# Altium schematic sheet Sheet4.SchDoc(Sheet4)
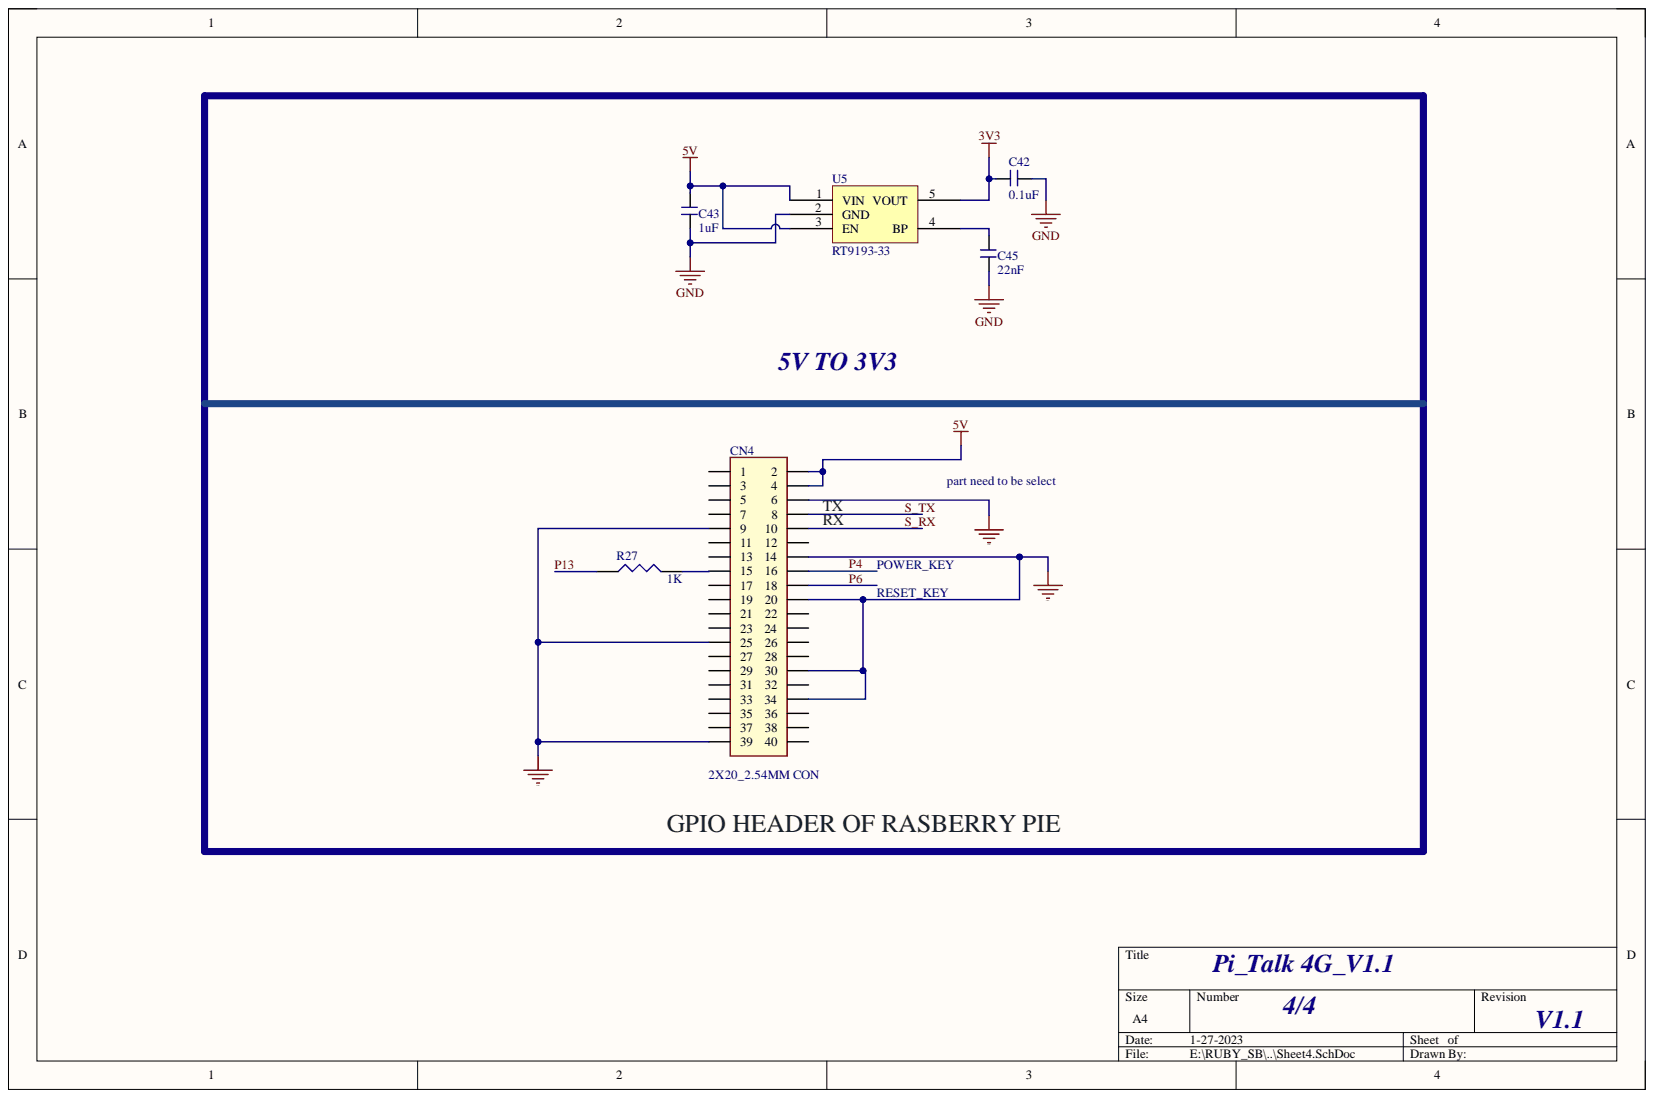
[diagram p0: Sheet4.SchDoc]

## Parts
C42  0.1uF  [CAP_0603_NONPOLAR]
C43  1uF  [CAP_0603_NONPOLAR]
C45  22nF  [CAP_0603_NONPOLAR]
CN4  2X20_2.54MM CON  [2X20_2.54mm_CON_40P]
R27  1K  [0603]
U5  RT9193-33  [SOT-23-5]

## Nets
3V3: C42.2 U5.5(VOUT)
5V: C43.1 CN4.2 CN4.4 U5.1(VIN) U5.3(EN)
GND: C42.1 C43.2 C45.2 CN4.6 CN4.9 CN4.14 CN4.20 CN4.25 CN4.30 CN4.34 CN4.39 U5.2(GND)
P4: CN4.16
P6: CN4.18
P13: R27.1
S_RX: CN4.10
S_TX: CN4.8
NetC45_1: C45.1 U5.4(BP)
NetCN4_15: CN4.15 R27.2

Not connected: CN4 1 3 5 7 11 12 13 17 19 21 22 23 24 26 27 28 29 31 32 33 35 36 37 38 40

## Library data of the parts
C42 C43 C45 = CAP_NONPOLAR: Component Kind: Standard; Library Name: dxp_pasl_lib.IntLib
CN4 = CON40_DD: Component Kind: Standard; Library Name: dxp_pasl_lib.IntLib
R27 = RES: Component Kind: Standard; Library Name: dxp_pasl_lib.IntLib
U5 = RT9193-33: Component Kind: Standard; Library Name: dxp_pasl_lib.IntLib
